# Altium schematic sheet 05.GPS.SchDoc
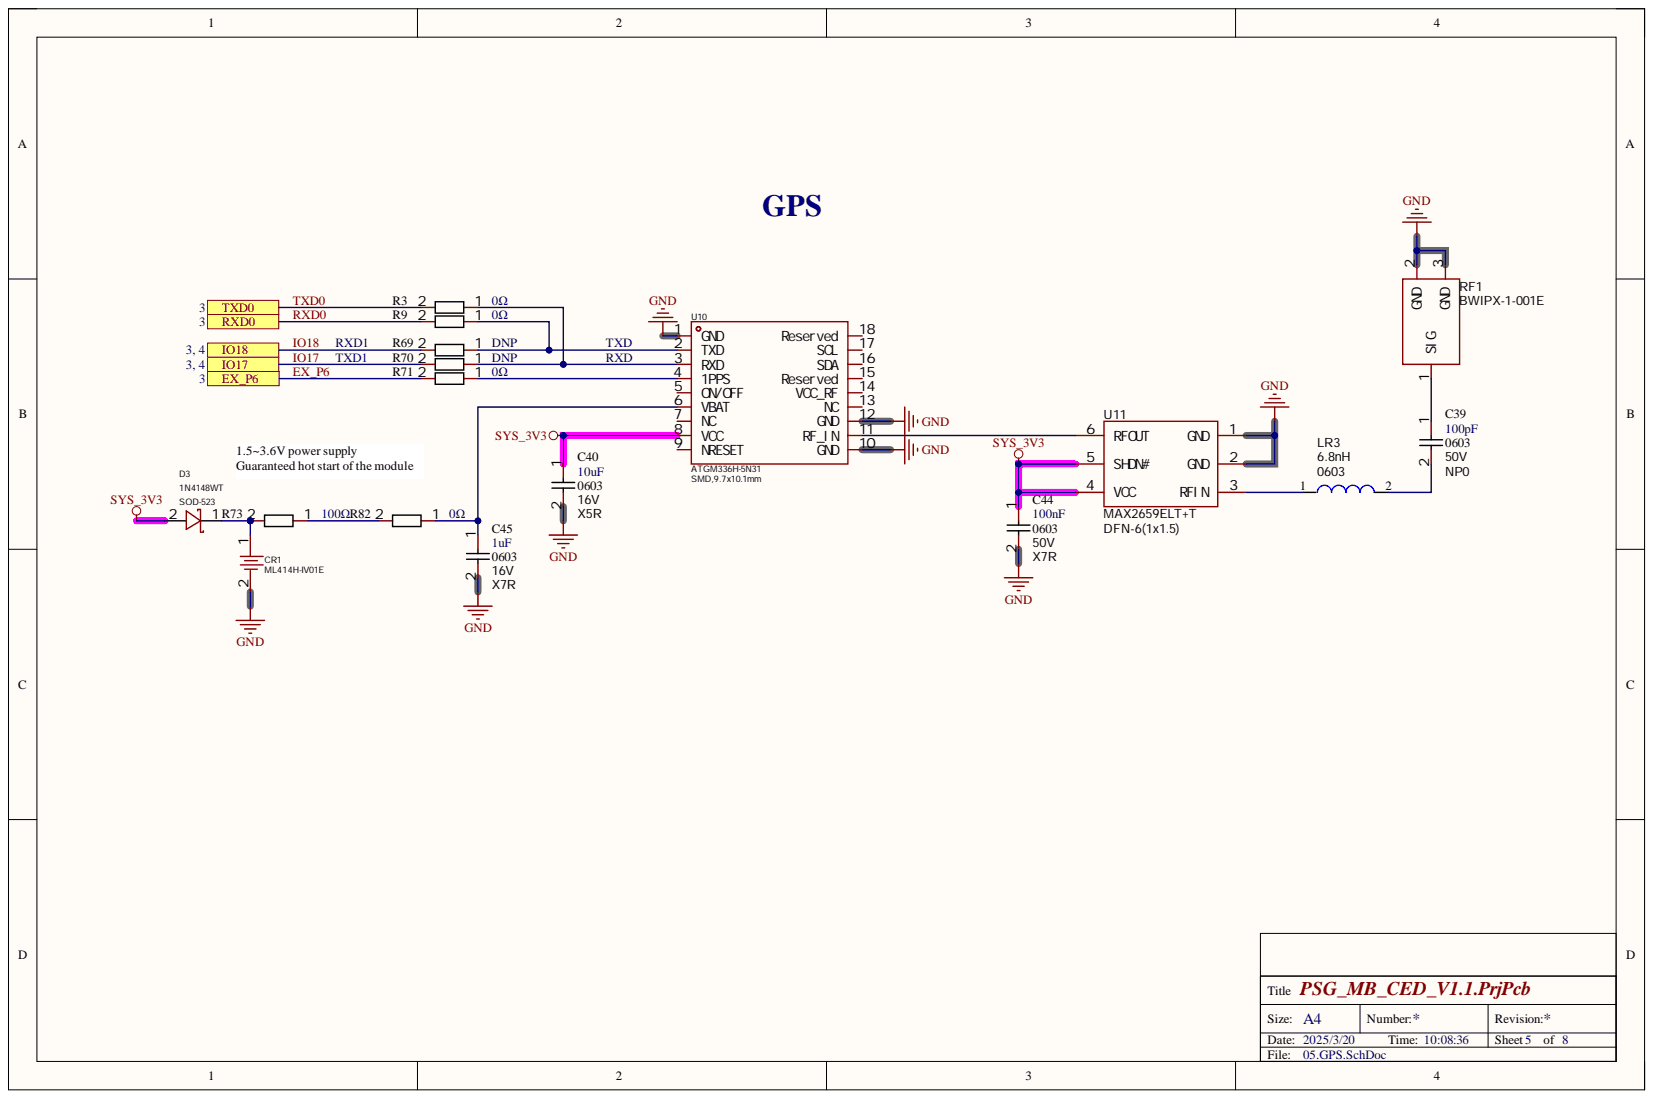
[diagram p0: 05.GPS.SchDoc]

## Parts
C39  100pF  Supplier Footprint: 0603  Temperature Coefficient: NP0  Voltage Rated: 50V  [C0603]
C40  10uF  Supplier Footprint: 0603  Temperature Coefficient: X5R  Voltage Rated: 16V  [C0603]
C44  100nF  Supplier Footprint: 0603  Temperature Coefficient: X7R  Voltage Rated: 50V  [C0603]
C45  1uF  Supplier Footprint: 0603  Temperature Coefficient: X7R  Voltage Rated: 16V  [C0603]
CR1  ML414H-IV01E  [BAT-SMD_ML414H-IV01E]
D3  1N4148WT  Supplier Footprint: SOD-523  [SOD_523]
LR3  6.8nH  Supplier Footprint: 0603  [L0603]
R3  0Ω  [R0603]
R9  0Ω  [R0603]
R69  DNP  [R0603]
R70  DNP  [R0603]
R71  0Ω  [R0603]
R73  100Ω  [R0603]
R82  0Ω  [R0603]
RF1  BWIPX-1-001E  [IPEX-SMD_BWIPX-1-001E]
U10  ATGM336H-5N31  Supplier Footprint: SMD,9.7x10.1mm  [GPSM-SMD_ATGM336H-TR]
U11  MAX2659ELT+T  Supplier Footprint: DFN-6(1x1.5)  [UDFN-6]

## Ports
EX_P6, IO17, IO18, RXD0, TXD0

## Nets
GND: C40.2 C44.2 C45.2 CR1.2 RF1.2 RF1.3 U10.1(GND) U10.10(GND) U10.12(GND) U11.1(GND) U11.2(GND)
SYS_3V3: C40.1 C44.1 D3.2 U10.8(VCC) U11.4(VCC) U11.5(SHDN#)
EX_P6: R71.2 ; port EX_P6
IO17: R70.2 ; port IO17
IO18: R69.2 ; port IO18
RXD0: R9.2 ; port RXD0
TXD0: R3.2 ; port TXD0
NetC39_1: C39.1 RF1.1
NetC39_2: C39.2 LR3.2
NetC45_1: C45.1 R82.1 U10.6(VBAT)
NetCR1_1: CR1.1 D3.1 R73.2
NetLR3_1: LR3.1 U11.3(RFIN)
NetR3_1: R3.1 R70.1 U10.3(RXD)
NetR9_1: R9.1 R69.1 U10.2(TXD)
NetR71_1: R71.1 U10.4(1PPS)
NetR73_1: R73.1 R82.2
NetU10_11: U10.11(RF_IN) U11.6(RFOUT)

Not connected: U10 5(ON/OFF) 7(NC) 9(NRESET) 13(NC) 14(VCC_RF) 15(Reserved) 16(SDA) 17(SCL) 18(Reserved)

## Library data of the parts
C39 = CC0603JRNPO9BN120: Manufacturer: YAGEO(国巨); Add into BOM: yes; Device: CC0603JRNPO9BN101; Name: 贴片电容; Tolerance: ±5%; Component Kind: Standard; Convert to PCB: yes; Datasheet: https://atta.szlcsc.com/upload/public/pdf/source/20181008/C309499_21CC21451B82C39E3D92150FD6B30293.pdf; JLCPCB Part Class: Extended Part; LCSC Part Name: 100pF ±5% 50V; Manufacturer Part: CC0603JRNPO9BN101; Supplier: LCSC; Supplier Part: C14665; Symbol: CC0603JRNPO9BN101; Value: 100pF
C40 = CC0603JRNPO9BN120: Manufacturer: YAGEO(国巨); Add into BOM: yes; Device: CC0603MRX5R7BB106; Name: 贴片电容; Tolerance: ±20%; Component Kind: Standard; Convert to PCB: yes; Datasheet: https://atta.szlcsc.com/upload/public/pdf/source/20180326/C109457_7BA80561F4CBA4554497C3A4BE33D2CE.pdf; JLCPCB Part Class: Extended Part; LCSC Part Name: 10uF ±20% 16V; Manufacturer Part: CC0603MRX5R7BB106; Supplier: LCSC; Supplier Part: C109457; Symbol: CC0603MRX5R7BB106; Value: 10uF
C44 = CC0603JRNPO9BN120: Manufacturer: YAGEO(国巨); Add into BOM: yes; Device: CC0603KRX7R9BB104; Name: 贴片电容; Tolerance: ±10%; Component Kind: Standard; Convert to PCB: yes; Datasheet: https://atta.szlcsc.com/upload/public/pdf/source/20180910/C282072_33301C7F631E79921B82A8DAC6BCC5B3.pdf; JLCPCB Part Class: Basic Part; LCSC Part Name: 100nF ±10% 50V; Manufacturer Part: CC0603KRX7R9BB104; Supplier: LCSC; Supplier Part: C14663; Symbol: CC0603KRX7R9BB104; Value: 100nF
C45 = CC0603JRNPO9BN120: Manufacturer: YAGEO(国巨); Add into BOM: yes; Device: CC0603KRX7R7BB105; Name: 贴片电容; Tolerance: ±10%; Component Kind: Standard; Convert to PCB: yes; Datasheet: https://atta.szlcsc.com/upload/public/pdf/source/20180910/C282072_33301C7F631E79921B82A8DAC6BCC5B3.pdf; JLCPCB Part Class: Extended Part; LCSC Part Name: 1uF ±10% 16V; Manufacturer Part: CC0603KRX7R7BB105; Supplier: LCSC; Supplier Part: C106248; Symbol: CC0603KRX7R7BB105; Value: 1uF
CR1 = ML414H-IV01E: Manufacturer: Seiko(精工); Add into BOM: yes; appearance: 纽扣; Battery chargeability: 可充电; battery type: 电池; capacity: 1mAh; chemical composition: 锂离子; Foot type: 卧式焊脚; voltage: 3V; battery model: -; Component Kind: Standard; Convert to PCB: yes; Datasheet: https://atta.szlcsc.com/upload/public/pdf/source/20240813/3753C7A82D2F6B2E19826E6DCCF42700.pdf; JLCPCB Part Class: Extended Part; LCSC Part Name: Seiko(精工) 锂离子电池 3V 1mAh 1个; Manufacturer Part: ML414H-IV01E; PCB3D: BAT-SMD_ML414H-IV01E; size: 4mm×1mm; Supplier: LCSC; Supplier Footprint: -; Supplier Part: C167511; Symbol: ML414H-IV01E; Type of electrolyte: -; Value: ML414H-IV01E
D3 = SS34_C8678: Manufacturer: TWGMC(台湾迪嘉); Add into BOM: yes; Diode Configuration: 独立式; Forward Voltage (Vf@If): 1.25V@150mA; Rectified Current: 125mA; Reverse Leakage Current (Ir): 1uA; Reverse Voltage (Vr): 100V; Symbol: 1N4148WT_C727112; Component Kind: Standard; Convert to PCB: yes; Datasheet: https://atta.szlcsc.com/upload/public/pdf/source/20200828/C727112_FCA71CBB186A515518FBD85DA79D41CF.pdf; JLCPCB Part Class: Extended Part; LCSC Part Name: 1N4148WT; Manufacturer Part: 1N4148WT; Supplier: LCSC; Supplier Part: C727112; Value: 1N4148WT
LR3 = BLM18KG121TN1D: Manufacturer: muRata(村田); Manufacturer Part: LQW18AN6N8C00D; Add into BOM: yes; Circuits: 1; Current Rating: 500mA; DC Resistance: 380mΩ; Impedance @ Frequency: 600Ω@100MHz; Tolerance: ±25%; Component Kind: Standard; Convert to PCB: yes; Datasheet: https://atta.szlcsc.com/upload/public/pdf/source/20180326/C86136_7D4C2B2C0A8359F5C7679A70DBFFC85D.pdf; JLCPCB Part Class: Extended Part; LCSC Part Name: 6.8nH; Library Name: BSMD0805-100-6V1_BSMD0805-100-6V.SCHLIB; Supplier: LCSC; Supplier Part: C86136; Symbol: LQW18AN6N8C00D; Value: 6.8nH
R3 R9 R69-R71 R82 = 0603WAF1003T5E: Manufacturer: YAGEO(国巨); Add into BOM: yes; Device: RC0603FR-070RL; Name: 贴片电阻; Overload Voltage (Max): 75V; Power(Watts): 100mW; Component Kind: Standard; Convert to PCB: yes; Datasheet: https://atta.szlcsc.com/upload/public/pdf/source/20180316/70C0E2BDAE7FCC62C531A5A58D202EE1.pdf; JLCPCB Part Class: Extended Part; LCSC Part Name: 厚膜电阻 0Ω ±1% 100mW; Manufacturer Part: RC0603FR-070RL; Operating Temperature Range: -55℃~+155℃; Supplier: LCSC; Supplier Part: C100044; Symbol: RC0603FR-070RL; Temperature Coefficient: -; Type: 厚膜电阻
  R3 R9 R71 R82: Value: 0Ω
  R69 R70: Value: DNP
R73 = 0603WAF1003T5E: Manufacturer: YAGEO(国巨); Add into BOM: yes; Device: RC0603FR-07100RL; Name: 贴片电阻; Overload Voltage (Max): 75V; Power(Watts): 100mW; Temperature Coefficient: ±100ppm/℃; Component Kind: Standard; Convert to PCB: yes; Datasheet: https://atta.szlcsc.com/upload/public/pdf/source/20180316/70C0E2BDAE7FCC62C531A5A58D202EE1.pdf; JLCPCB Part Class: Extended Part; LCSC Part Name: 厚膜电阻 100Ω ±1% 100mW; Manufacturer Part: RC0603FR-07100RL; Operating Temperature Range: -55℃~+155℃; Supplier: LCSC; Supplier Part: C105588; Symbol: RC0603FR-07100RL; Type: 厚膜电阻; Value: 100Ω
RF1 = BWIPX-1-001E: Manufacturer: BAT WIRELESS(蝙蝠无线); Add into BOM: yes; Connector Type: 板端; Frequency-Max: 6GHz; Impedance: 50Ω; Interface Type: 内针; Number of Ports: 1; RF Series: IPEX; Component Kind: Standard; Convert to PCB: yes; Datasheet: https://atta.szlcsc.com/upload/public/pdf/source/20201223/C496552_05E5A9956EAE1A8819C5051D085B1DED.pdf; Interface Diameter: -; Interface Length/Height: -; JLCPCB Part Class: Extended Part; LCSC Part Name: IPEX 内针; Library Name: BWIPX-1-001E1_BWIPX-1-001E.SCHLIB; Manufacturer Part: BWIPX-1-001E; Operating Temperature Range: -; PCB3D: IPEX-SMD_BWIPX-1-001E; Style: -; Supplier: LCSC; Supplier Footprint: SMD; Supplier Part: C496552; Symbol: BWIPX-1-001E; Value: BWIPX-1-001E
U10 = ATGM336H-5N31: Manufacturer: 杭州中科微; Add into BOM: yes; Component Kind: Standard; Convert to PCB: yes; Datasheet: https://atta.szlcsc.com/upload/public/pdf/source/20161226/1482720799860.pdf; JLCPCB Part Class: Extended Part; LCSC Part Name: BDS/GNSS 全星座定位导航模块; Manufacturer Part: ATGM336H-5N31; PCB3D: GPSM-SMD_L10.1-W9.7-H2.4-P1.1; Supplier: LCSC; Supplier Part: C90770; Symbol: ATGM336H-5N31; Value: ATGM336H-5N31
U11 = MAX2659ELT+T: Manufacturer: ADI(亚德诺)/MAXIM(美信); Add into BOM: yes; Gain: 20.5dB; Noise Figure: 0.8dB; P1dB: -12dBm; Supply Current: 4.1mA; Supply Voltage: 1.6V~3.6V; Component Kind: Standard; Convert to PCB: yes; Datasheet: https://atta.szlcsc.com/upload/public/pdf/source/20140314/1457707018660.pdf; JLCPCB Part Class: Extended Part; LCSC Part Name: GPS/GNSS低噪声放大器; Manufacturer Part: MAX2659ELT+T; Operating Temperature: -40℃~+85℃; PCB3D: UDFN-6; Supplier: LCSC; Supplier Part: C28043; Symbol: MAX2659ELT+T; Value: MAX2659ELT+T
